# Altium schematic sheet NRF.Sch
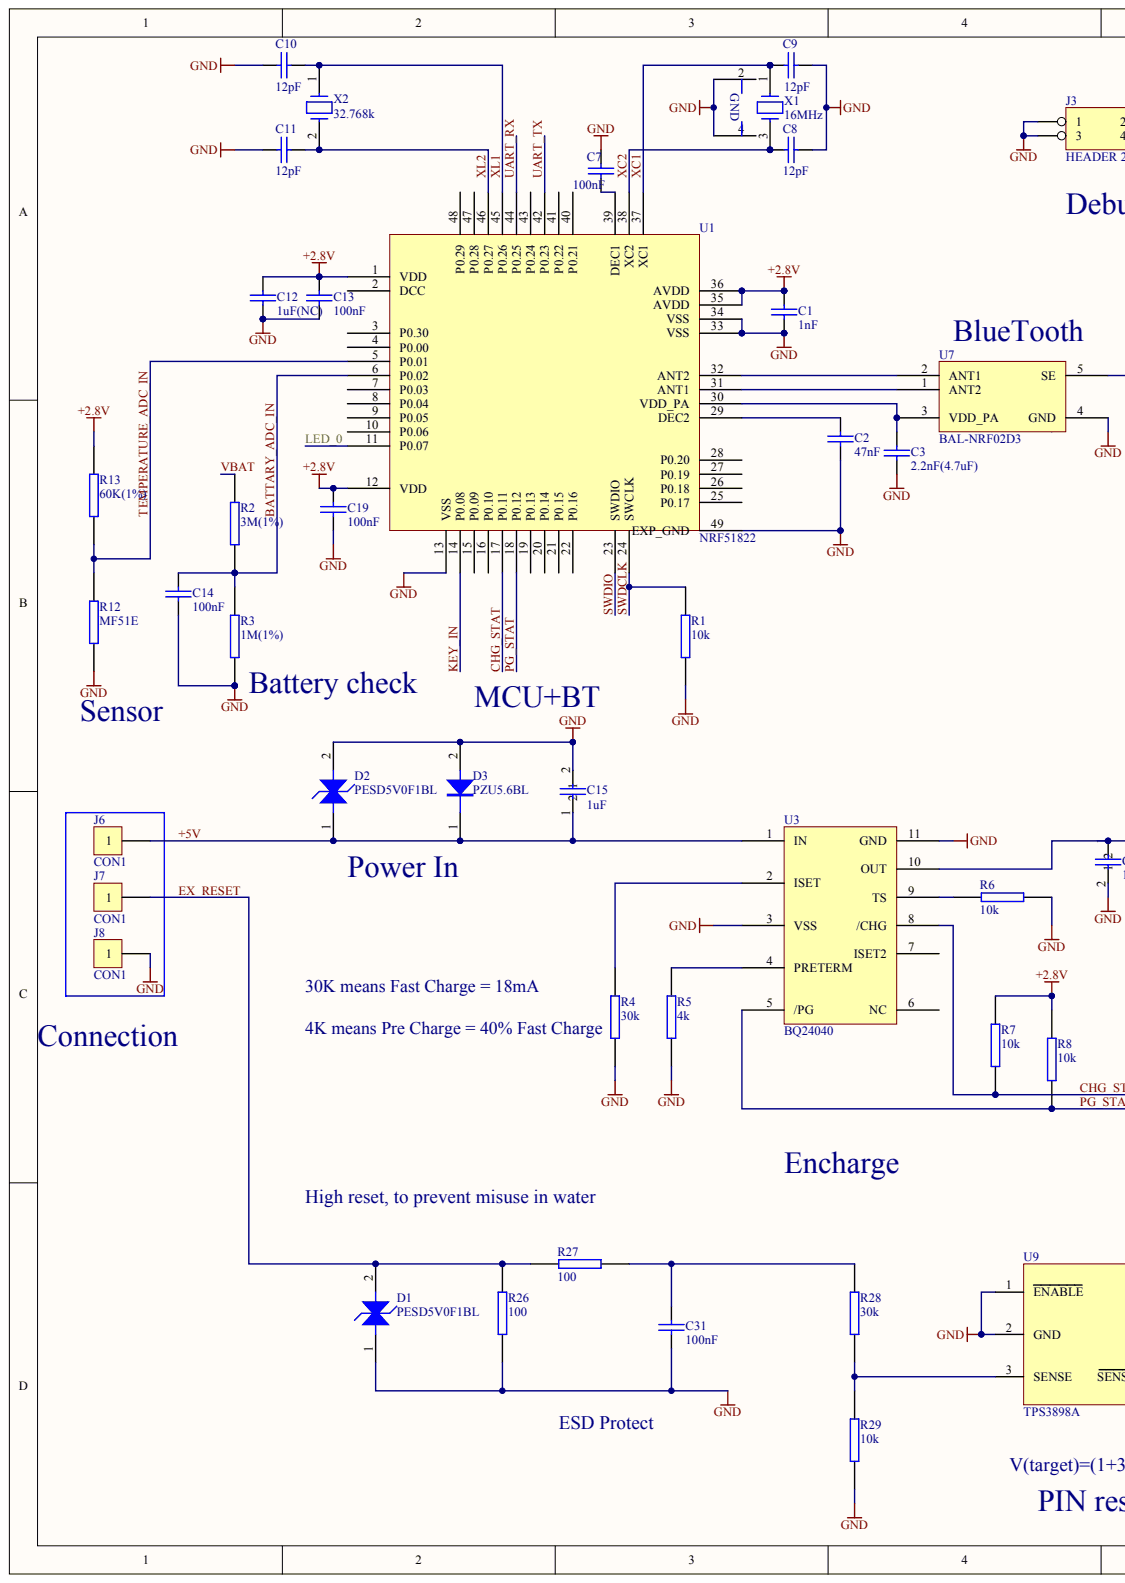
[diagram p0: NRF.Sch, left]
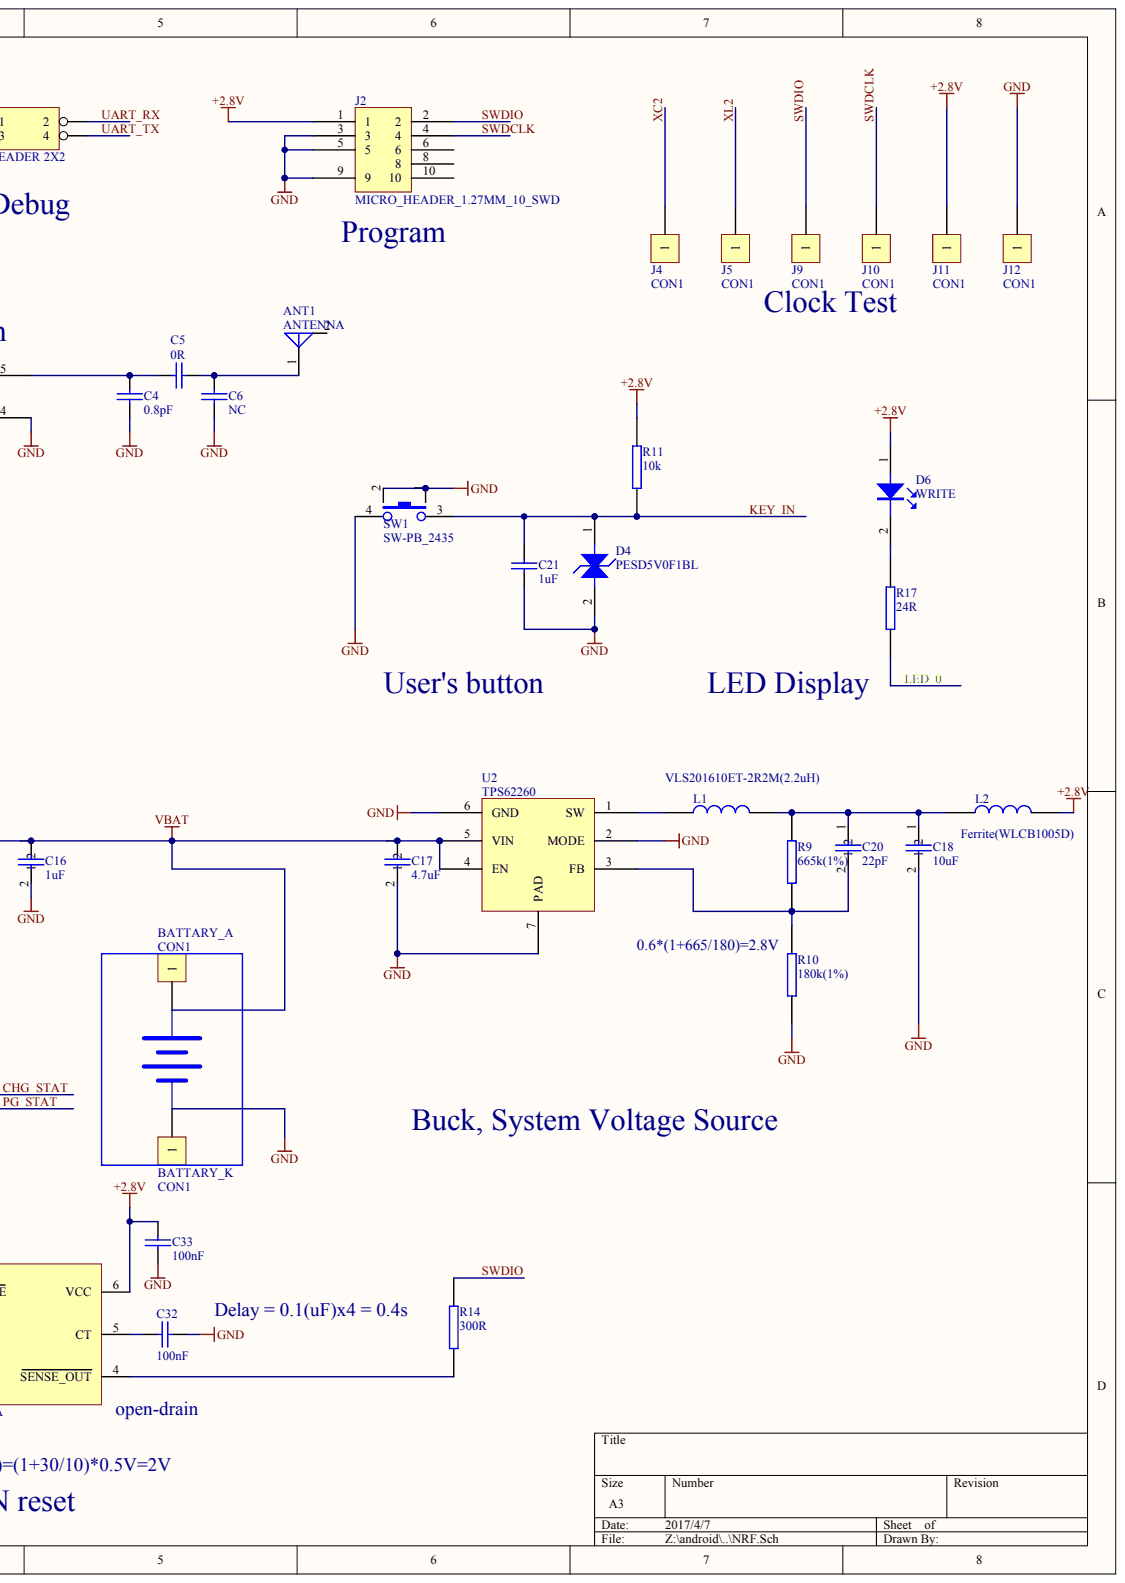
[diagram p1: NRF.Sch, right]

## Parts
ANT1  ANTENNA
BATTARY_A  CON1
BATTARY_K  CON1
C1  1nF
C2  47nF
C3  2.2nF(4.7uF)
C4  0.8pF
C5  0R
C6  NC
C7  100nF
C8  12pF
C9  12pF
C10  12pF
C11  12pF
C12  1uF(NC)
C13  100nF
C14  100nF
C15  1uF
C16  1uF
C17  4.7uF
C18  10uF
C19  100nF
C20  22pF
C21  1uF
C31  100nF
C32  100nF
C33  100nF
D1  PESD5V0F1BL
D2  PESD5V0F1BL
D3  PZU5.6BL
D4  PESD5V0F1BL
D6  WRITE
J2  MICRO_HEADER_1.27MM_10_SWD
J3  HEADER 2X2
J4  CON1
J5  CON1
J6  CON1
J7  CON1
J8  CON1
J9  CON1
J10  CON1
J11  CON1
J12  CON1
L1  VLS201610ET-2R2M(2.2uH)
L2  Ferrite(WLCB1005D)
R1  10k
R2  3M(1%)
R3  1M(1%)
R4  30k
R5  4k
R6  10k
R7  10k
R8  10k
R9  665k(1%)
R10  180k(1%)
R11  10k
R12  MF51E
R13  60K(1%)
R14  300R
R17  24R
R26  100
R27  100
R28  30k
R29  10k
SW1  SW-PB_2435
U1  NRF51822
U2  TPS62260
U3  BQ24040
U7  BAL-NRF02D3
U9  TPS3898A
X1  16MHz
X2  32.768k

## Nets
+2.8V: C1.1 C12.1 C13.1 C19.1 C33.1 D6.1 J2.1 J11.1 L2.2 R7.1 R8.1 R11.2 R13.2 U1.1(VDD) U1.12(VDD) U1.35(AVDD) U1.36(AVDD) U9.6(VCC)
GND: BATTARY_K.1 C1.2 C2.2 C3.2 C4.1 C6.1 C7.2 C8.1 C9.1 C10.2 C11.2 C12.2 C13.2 C14.2 C15.2 C16.2 C17.2 C18.2 C19.2 C21.2 C31.2 C32.2 C33.2 D1.1 D2.2 D3.2 D4.2 J2.3 J2.5 J2.9 J3.1 J3.3 J8.1 J12.1 R1.1 R3.1 R4.2 R5.2 R6.2 R10.1 R12.1 R26.2 R29.2 SW1.1 SW1.2 SW1.4 U1.13(VSS) U1.33(VSS) U1.34(VSS) U1.49(EXP_GND) U2.2(MODE) U2.6(GND) U2.7(PAD) U3.3(VSS) U3.11(GND) U7.4(GND) U9.1(ENABLE) U9.2(GND) X1.2 X1.4
+5V: C15.1 D2.1 D3.1 J6.1 U3.1(IN)
BATTARY_ADC_IN: C14.1 R2.1 R3.2 U1.6(P0.02)
CHG_STAT: R7.2 U1.17(P0.11) U3.8(/CHG)
EX_RESET: D1.2 J7.1 R26.1 R27.2
KEY_IN: C21.1 D4.1 R11.1 SW1.3 U1.14(P0.08)
LED_0: R17.1 U1.11(P0.07)
PG_STAT: R8.2 U1.18(P0.12) U3.5(/PG)
SWDCLK: J2.4 J10.1 R1.2 U1.24(SWCLK)
SWDIO: J2.2 J9.1 R14.1 U1.23(SWDIO)
TEMPERATURE_ADC_IN: R12.2 R13.1 U1.5(P0.01)
UART_RX: J3.2 U1.44(P0.25)
UART_TX: J3.4 U1.42(P0.23)
VBAT: BATTARY_A.1 C16.1 C17.1 R2.2 U2.4(EN) U2.5(VIN) U3.10(OUT)
XC1: C9.2 U1.37(XC1) X1.1
XC2: C8.2 J4.1 U1.38(XC2) X1.3
XL1: C10.1 U1.45(P0.26) X2.1
XL2: C11.1 J5.1 U1.46(P0.27) X2.2
NetANT1_1: ANT1.1 C5.1 C6.2
NetC2_1: C2.1 U1.29(DEC2)
NetC3_1: C3.1 U1.30(VDD_PA) U7.3(VDD_PA)
NetC4_2: C4.2 C5.2 U7.5(SE)
NetC7_1: C7.1 U1.39(DEC1)
NetC18_1: C18.1 C20.1 L1.2 L2.1 R9.2
NetC20_2: C20.2 R9.1 R10.2 U2.3(FB)
NetC31_1: C31.1 R27.1 R28.1
NetC32_1: C32.1 U9.5(CT)
NetD6_2: D6.2 R17.2
NetL1_1: L1.1 U2.1(SW)
NetR4_1: R4.1 U3.2(ISET)
NetR5_1: R5.1 U3.4(PRETERM)
NetR6_1: R6.1 U3.9(TS)
NetR14_2: R14.2 U9.4(SENSE_OUT)
NetR28_2: R28.2 R29.1 U9.3(SENSE)
NetU1_31: U1.31(ANT1) U7.1(ANT2)
NetU1_32: U1.32(ANT2) U7.2(ANT1)
N00009:

Not connected: ANT1 2; J2 6 8 10; U1 2(DCC) 3(P0.30) 4(P0.00) 7(P0.03) 8(P0.04) 9(P0.05) 10(P0.06) 15(P0.09) 16(P0.10) 19(P0.13) 20(P0.14) 21(P0.15) 22(P0.16) 25(P0.17) 26(P0.18) 27(P0.19) 28(P0.20) 40(P0.21) 41(P0.22) 43(P0.24) 47(P0.28) 48(P0.29); U3 6(NC) 7(ISET2)
